# Altium schematic sheet 1_CONNECTORS.SchDoc(1_CONNECTORS)
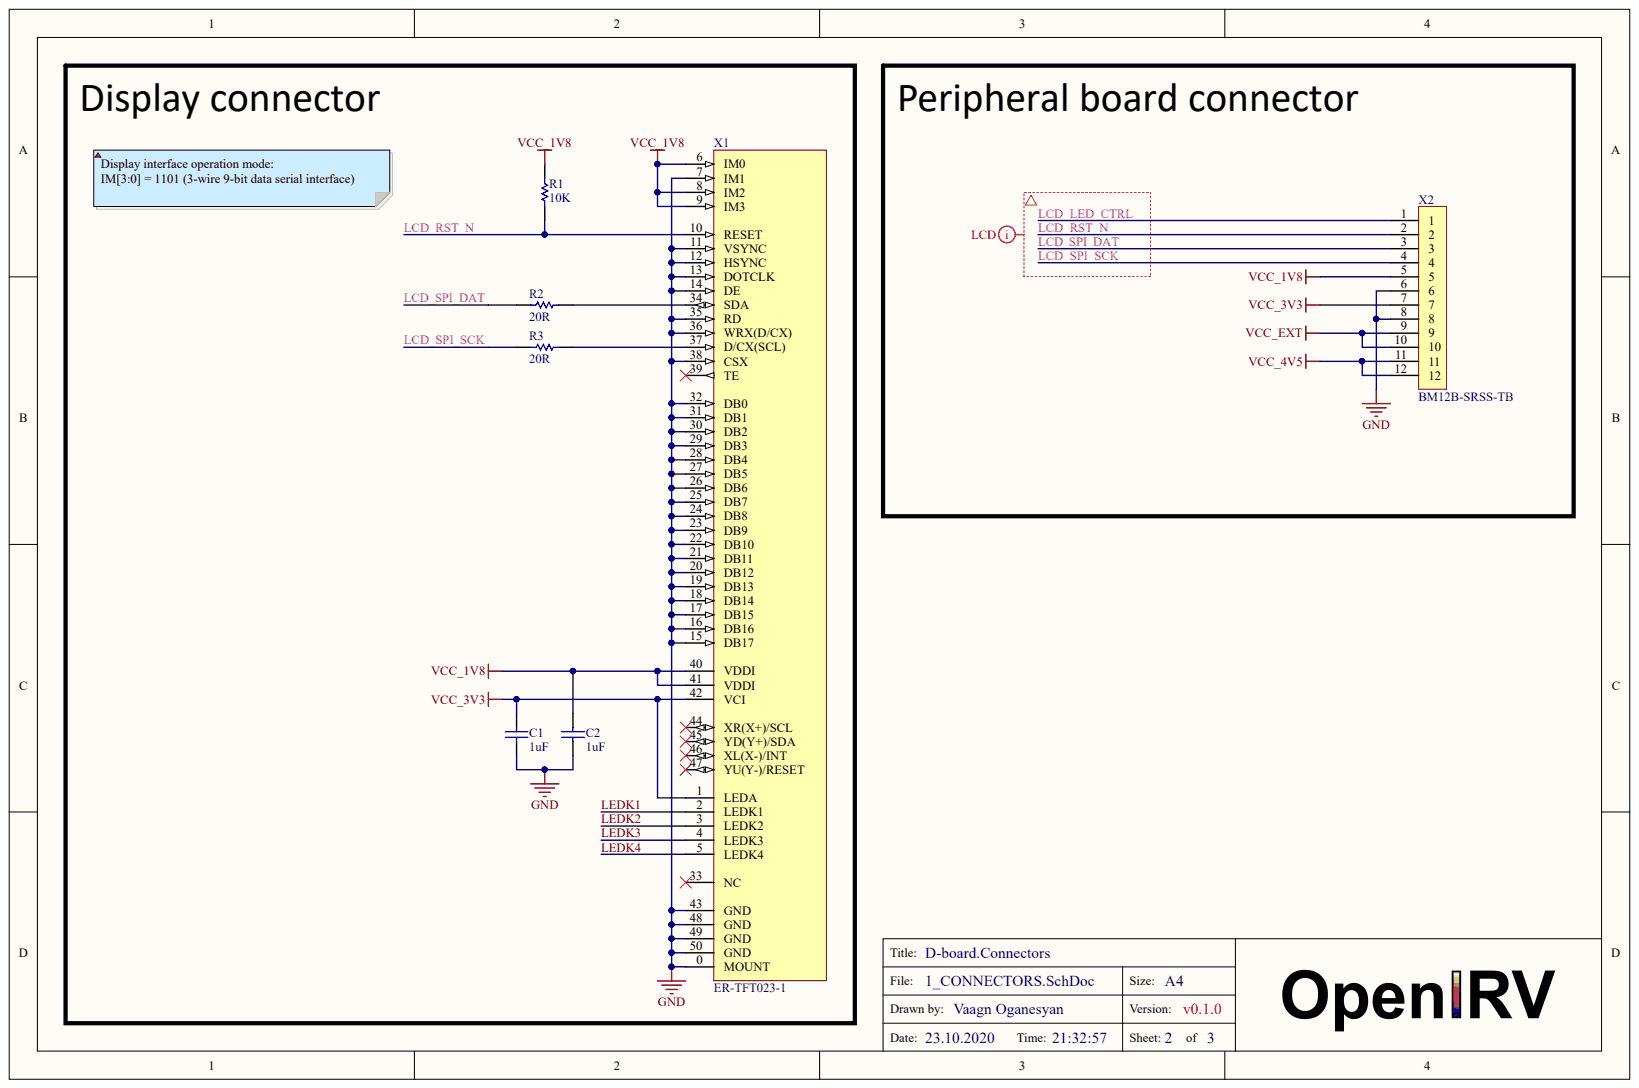
[diagram p0: 1_CONNECTORS.SchDoc]

## Parts
C1  1uF  [C0603[1608]]
C2  1uF  [C0603[1608]]
R1  10K  [R0402[1005]]
R2  20R  [R0402[1005]]
R3  20R  [R0402[1005]]
X1  ER-TFT023-1  [XF2M-5015-1A]
X2  BM12B-SRSS-TB  [BM12B-SRSS-TB]

## Nets
GND: C1.1 C2.1 X1.0(MOUNT) X1.7(IM1) X1.11(VSYNC) X1.12(HSYNC) X1.13(DOTCLK) X1.14(DE) X1.15(DB17) X1.16(DB16) X1.17(DB15) X1.18(DB14) X1.19(DB13) X1.20(DB12) X1.21(DB11) X1.22(DB10) X1.23(DB9) X1.24(DB8) X1.25(DB7) X1.26(DB6) X1.27(DB5) X1.28(DB4) X1.29(DB3) X1.30(DB2) X1.31(DB1) X1.32(DB0) X1.35(RD) X1.36(WRX(D/CX)) X1.38(CSX) X1.43(GND) X1.48(GND) X1.49(GND) X1.50(GND) X2.6 X2.8
VCC_1V8: C2.2 R1.2 X1.6(IM0) X1.8(IM2) X1.9(IM3) X1.40(VDDI) X1.41(VDDI) X2.5
VCC_3V3: C1.2 X1.1(LEDA) X1.42(VCI) X2.7
VCC_4V5: X2.11 X2.12
VCC_EXT: X2.9 X2.10
LCD_LED_CTRL: X2.1
LCD_RST_N: R1.1 X1.10(RESET) X2.2
LCD_SPI_DAT: R2.1 X2.3
LCD_SPI_SCK: R3.1 X2.4
LEDK1: X1.2(LEDK1)
LEDK2: X1.3(LEDK2)
LEDK3: X1.4(LEDK3)
LEDK4: X1.5(LEDK4)
NetR2_2: R2.2 X1.34(SDA)
NetR3_2: R3.2 X1.37(D/CX(SCL))
N00010:

Not connected: X1 33(NC) 39(TE) 44(XR(X+)/SCL) 45(YD(Y+)/SDA) 46(XL(X-)/INT) 47(YU(Y-)/RESET)

## Library data of the parts
C1 C2 = CAP: Dielectric: X7R; Tolerance: 10%; Voltage: 25v; Component Kind: Standard; Library Name: general.IntLib; Mounting: SMD; Value: 1uF
R1-R3 = RES: Power: 0.06W; Tolerance: 5%; Component Kind: Standard; Library Name: general.IntLib; Mounting: SMD
  R1: Value: 10K
  R2 R3: Value: 20R
X1 = ER-TFT023-1: TFT LCD Display 2.3"; Component Kind: Standard; Library Name: general.IntLib
X2 = BM12B-SRSS-TB: Component Kind: Standard; Library Name: general.IntLib
